# Altium schematic sheet TalonSRXBreakout.SchDoc(TalonSRXBreakout)
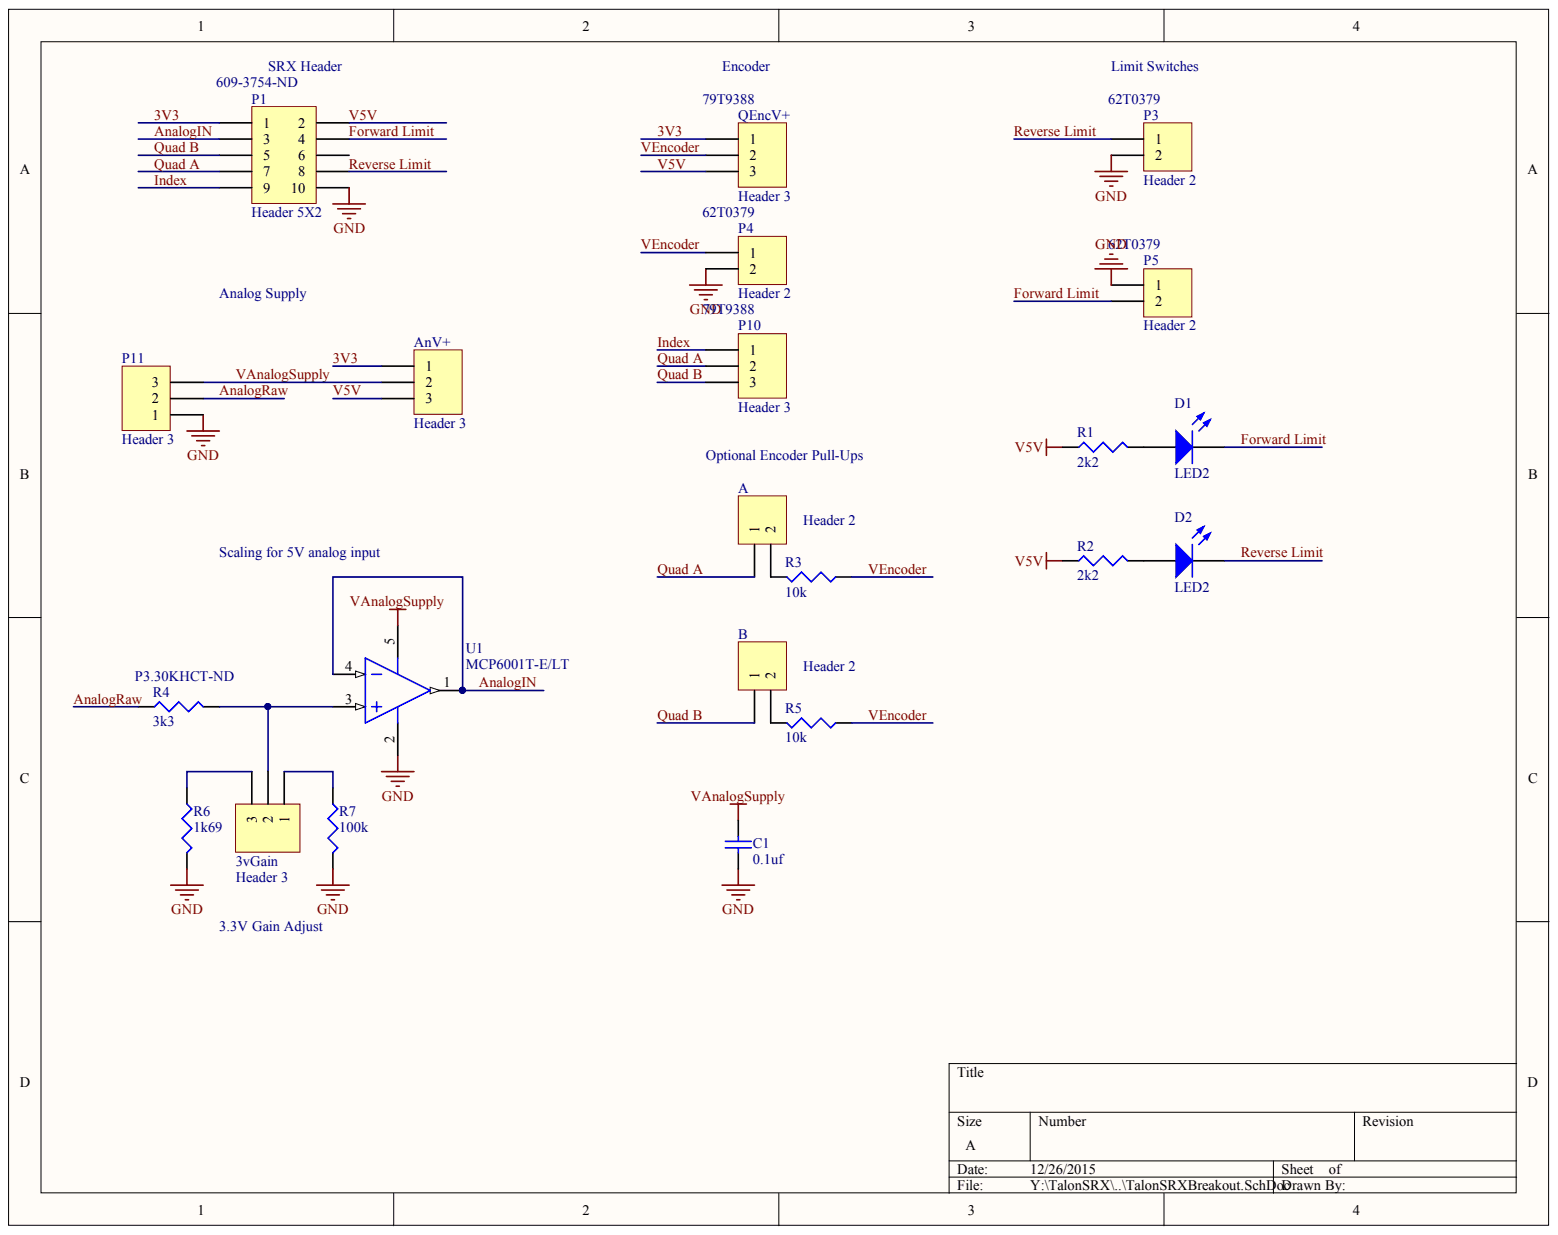
[diagram p0: TalonSRXBreakout.SchDoc]

## Parts
3vGain  Header 3  [3 Pad Jumper]
A  Header 2  [2 Pad Jumper]
AnV+  Header 3  [3 Pad Jumper]
B  Header 2  [2 Pad Jumper]
C1  0.1uf  [CAP 0603 (1608)]
D1  LED2  [DIODE 0603/SOD-523F]
D2  LED2  [DIODE 0603/SOD-523F]
P1  Header 5X2  Supplier Part Number 1: 609-3754-ND  [HDR2X5 - 50Mil]
P3  Header 2  Supplier Part Number 1: 62T0379  [Wago 2 Pin]
P4  Header 2  Supplier Part Number 1: 62T0379  [Wago 2 Pin]
P5  Header 2  Supplier Part Number 1: 62T0379  [Wago 2 Pin]
P10  Header 3  Supplier Part Number 1: 79T9388  [Wago 3 Pin]
P11  Header 3  [Wago 3 Pin]
QEncV+  Header 3  Supplier Part Number 1: 79T9388  [3 Pad Jumper]
R1  2k2  [RES 0603 (1608)]
R2  2k2  [RES 0603 (1608)]
R3  10k  [RES 0603 (1608)]
R4  3k3  Supplier Part Number 2: P3.30KHCT-ND  [RES 0603 (1608)]
R5  10k  [RES 0603 (1608)]
R6  1k69  [RES 0603 (1608)]
R7  100k  [RES 0603 (1608)]
U1  MCP6001T-E/LT  [SC70-LT5_N]

## Nets
GND: C1.1 P1.10 P3.2 P4.2 P5.1 P11.1 R6.2 R7.2 U1.2
3V3: AnV+.1 P1.1 QEncV+.1
ANALOGIN: P1.3 U1.1 U1.4
ANALOGRAW: P11.2 R4.1
FORWARD LIMIT: D1.2 P1.4 P5.2
INDEX: P1.9 P10.1
QUAD A: A.1 P1.7 P10.2
QUAD B: B.1 P1.5 P10.3
REVERSE LIMIT: D2.2 P1.8 P3.1
V5V: AnV+.3 P1.2 QEncV+.3 R1.1 R2.1
VANALOGSUPPLY: AnV+.2 C1.2 P11.3 U1.5
VENCODER: P4.1 QEncV+.2 R3.1 R5.1
Net3vGain_1: 3vGain.1 R7.1
Net3vGain_2: 3vGain.2 R4.2 U1.3
Net3vGain_3: 3vGain.3 R6.1
NetA_2: A.2 R3.2
NetB_2: B.2 R5.2
NetD1_1: D1.1 R1.2
NetD2_1: D2.1 R2.2

Not connected: P1 6

## Library data of the parts
3vGain AnV+ P10 P11 QEncV+ = Header 3: Header, 3-Pin; Component Kind: Standard; LatestRevisionDate: 24-Feb-2012; Signal Integrity: Connector; Library Name: Electron Custom Library.SchLib; Publisher: Electron
  P10 QEncV+: Supplier 1: Newark
A B P3-P5 = Header 2: Header, 2-Pin; Component Kind: Standard; Signal Integrity: Connector
  A B: LatestRevisionDate: 24-Feb-2012; Library Name: Electron Custom Library.SchLib; Publisher: Electron
  P3-P5: LatestRevisionDate: 17-Jul-2002; LatestRevisionNote: Re-released for DXP Platform.; Library Name: Miscellaneous Connectors.IntLib; Supplier 1: Newark; Publisher: Altium Limited
C1 = Capacitor Surface Mount: Component Kind: Standard; Description: Capacitor Surface Mount; Signal Integrity: Cap; Simulation: CAP; Supplier 1: Digi-Key; Supplier Part Number 1: 311-1344-1-ND; Value: 0.1uf; Library Name: Electron Custom Library.SchLib
D1 D2 = LED2: Typical RED, GREEN, YELLOW, AMBER GaAs LED; Component Kind: Standard; LatestRevisionDate: 17-Jul-2002; LatestRevisionNote: Re-released for DXP Platform.; Library Name: Miscellaneous Devices.IntLib; PackageDescription: SM LED; 2 Flat Leads; PackageReference: 3.2X1.6X1.1; PackageVersion: Feb-2002; Published: 8-Jun-2000; Simulation: LED2; Supplier 1: Digi-Key; Supplier Part Number 1: 160-1646-1-ND; Publisher: Altium Limited
P1 = Header 5X2: Header, 5-Pin, Dual row; Component Kind: Standard; LatestRevisionDate: 17-Jul-2002; LatestRevisionNote: Re-released for DXP Platform.; Library Name: Miscellaneous Connectors.IntLib; Signal Integrity: Connector; Supplier 1: Digi-Key; Publisher: Altium Limited
R1-R7 = Resistor Surface Mount: Component Kind: Standard; Description: Resistor Surface Mount; Signal Integrity: Res; Simulation: RESISTOR; Supplier 1: Digi-Key; Library Name: Electron Custom Library.SchLib
  R1 R2: Supplier Part Number 1: P2.2KJCT-ND; Value: 2k2
  R3 R5: Supplier Part Number 1: P10KGCT-ND; Value: 10k
  R4: Supplier 2: Digi-Key; Supplier Part Number 1: P3.3KHCT-ND; Value: 3k3
  R6: Supplier Part Number 1: P1.69KHCT-ND; Value: 1k69
  R7: Supplier Part Number 1: P100KHCT-ND; Value: 100k
U1 = MCP6001T-E/LT: 1 MHz, Low-Power Operational Amplifier, 5-Pin SC-70, Extended Temperature, Tape and Reel; Code_IPC: SOT353-5; Component Kind: Standard; ComponentLink1Description: Datasheet; ComponentLink1URL: http://ww1.microchip.com/downloads/en/DeviceDoc/21733j.pdf; ComponentLink2Description: Packaging Specification; ComponentLink2URL: http://www.microchip.com/stellent/groups/techpub_sg/documents/packagingspec/en012702.pdf; ComponentLink3Description: Microchip.com Home; ComponentLink3URL: http://www.microchip.com/; DatasheetVersion: revJ, Nov-2009; LatestRevisionDate: 02-Dec-2009; LatestRevisionNote: Updated to datasheet revision J, Nov-2009; Library Name: Microchip Linear Devices.IntLib; PackageDescription: 5-Lead Plastic Small Outline Transistor (LT) [SC70]; PackageReference: SC70-LT5; PackageVersion: revBB, Aug-2009; Published: 29-Jan-2009; Simulation: MCP6001; Supplier 1: Digi-Key; Supplier 2: Digi-Key; Supplier 3: Digi-Key; Supplier Part Number 1: MCP6001T-E/LTCT-ND; Supplier Part Number 2: MCP6001T-E/LTCT-ND; Supplier Part Number 3: MCP6001T-E/LTCT-ND; Publisher: Altium Limited
